# Altium schematic sheet Power_Supply.SchDoc
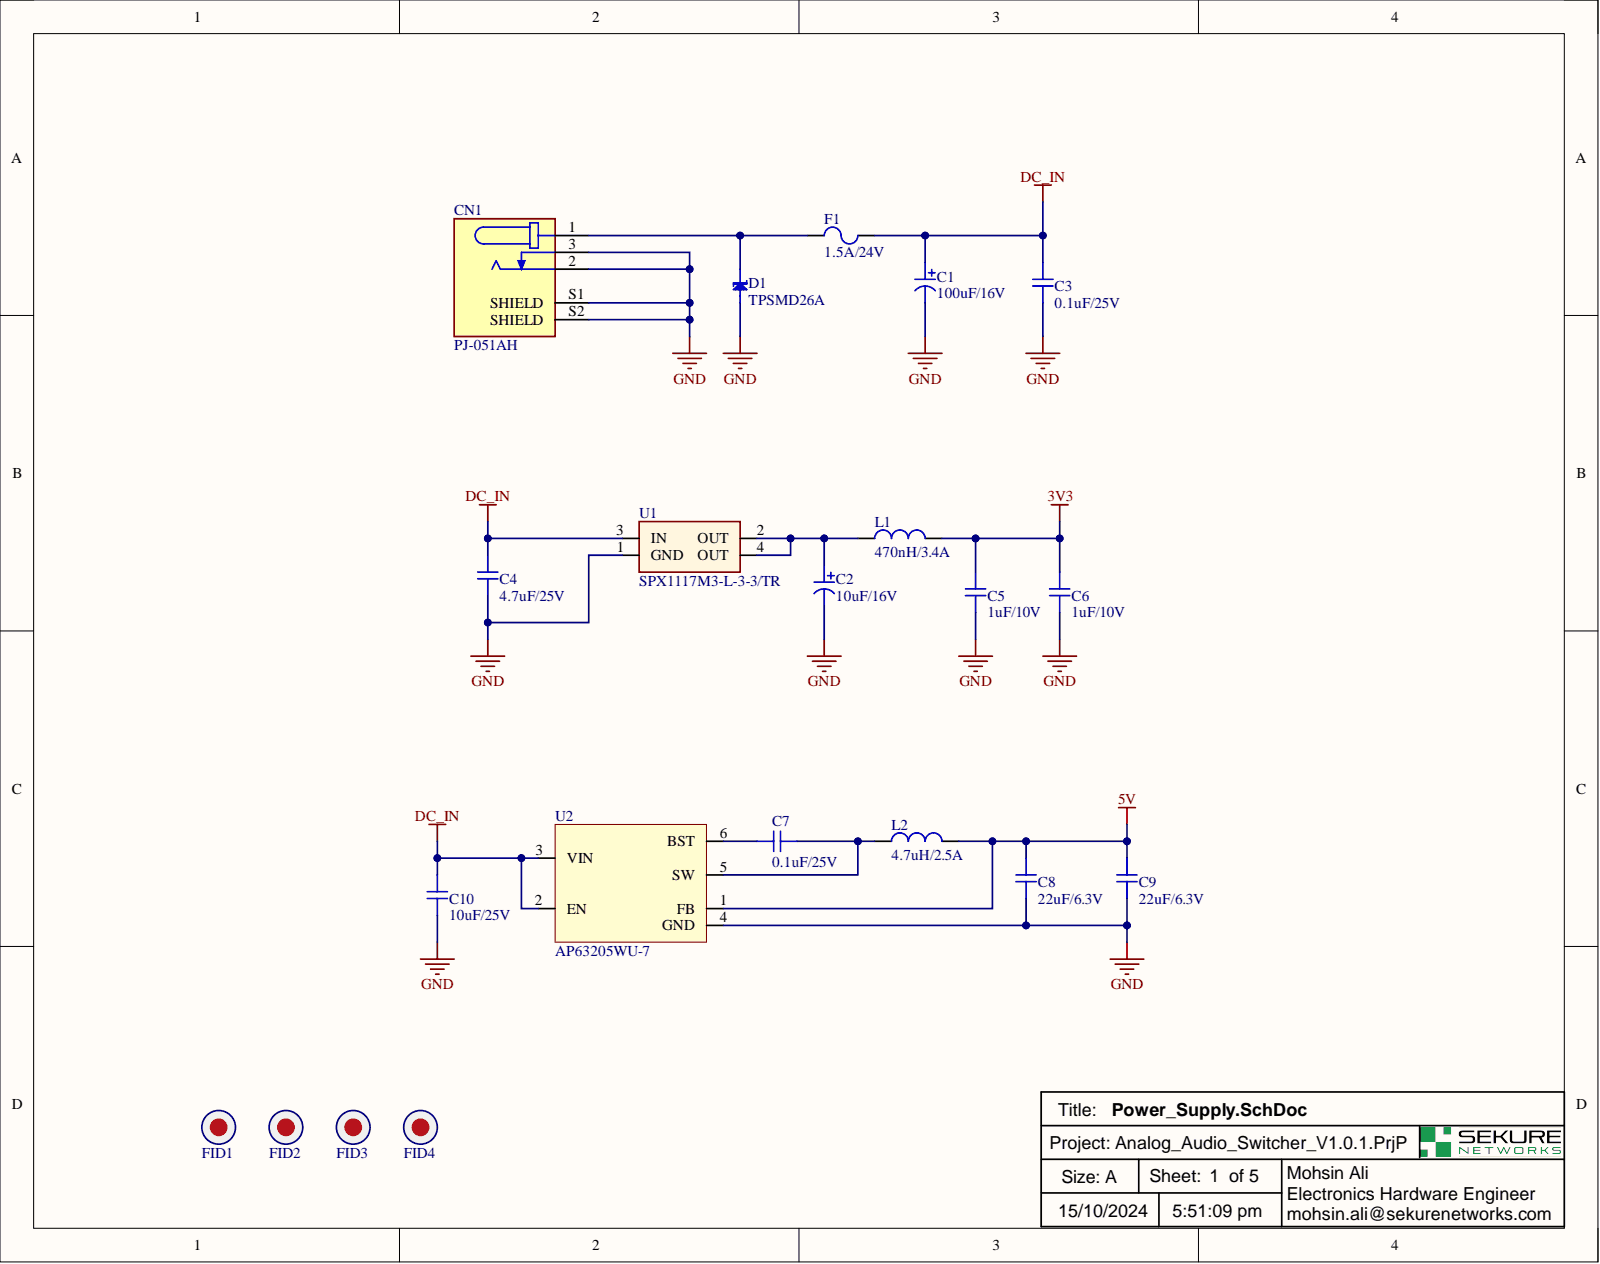
[diagram p0: Power_Supply.SchDoc]

## Parts
C1  value 100uF/16V  [CAP SMD 6.6 x 6.6]  (hidden comment: Capacitor)
C2  value 10uF/16V  [CAPAE4643X55M]  (hidden comment: Capacitor)
C3  value 0.1uF/25V  [CAP 0603]  (hidden comment: Ceramic Capacitor)
C4  value 4.7uF/25V  [CAP 0603]  (hidden comment: Ceramic Capacitor)
C5  value 1uF/10V  [CAP 0603]  (hidden comment: Ceramic Capacitor)
C6  value 1uF/10V  [CAP 0603]  (hidden comment: Ceramic Capacitor)
C7  value 0.1uF/25V  [CAP 0603]  (hidden comment: Ceramic Capacitor)
C8  value 22uF/6.3V  [CAP 0603]  (hidden comment: Ceramic Capacitor)
C9  value 22uF/6.3V  [CAP 0603]  (hidden comment: Ceramic Capacitor)
C10  value 10uF/25V  [CAP 0603]  (hidden comment: Capacitor)
CN1  value PJ-051AH  [PJ-051AH]  (hidden comment: Connector)
D1  value TPSMD26A  [DO-214AB(SMC)]  (hidden comment: TVS Diode)
F1  value 1.5A/24V  [0ZCG0150BF2C]  (hidden comment: Fuse)
FID1  Fiducal marks  [Fiducial mark]  (value not drawn)  (no BOM)
FID2  Fiducal marks  [Fiducial mark]  (value not drawn)  (no BOM)
FID3  Fiducal marks  [Fiducial mark]  (value not drawn)  (no BOM)
FID4  Fiducal marks  [Fiducial mark]  (value not drawn)  (no BOM)
L1  value 470nH/3.4A  [IND 0806]  (hidden comment: Inductor)
L2  value 4.7uH/2.5A  [B82472G6472M000]  (hidden comment: Inductor)
U1  value SPX1117M3-L-3-3/TR  [SOT-223]  (hidden comment: Regulator)
U2  value AP63205WU-7  [SOT23-6]  (hidden comment: Regulator)

## Nets
3V3: C5.2 C6.2 L1.2
5V: C8.1 C9.1 L2.2 U2.1(FB)
DC_IN: C1.1 C3.1 C4.1 C10.2 F1.2 U1.3(IN) U2.2(EN) U2.3(VIN)
GND: C1.2 C2.2 C3.2 C4.2 C5.1 C6.1 C8.2 C9.2 C10.1 CN1.2 CN1.3 CN1.S1(SHIELD) CN1.S2(SHIELD) D1.2 U1.1(GND) U2.4(GND)
NetC2_1: C2.1 L1.1 U1.2(OUT) U1.4(OUT)
NetC7_1: C7.1 U2.6(BST)
NetC7_2: C7.2 L2.1 U2.5(SW)
NetCN1_1: CN1.1 D1.1 F1.1

Not connected: FID1 0; FID2 0; FID3 0; FID4 0

## Library data of the parts
C1 = A765EB107M1CLAE025: Aluminum Electrolytic Capacitor, Polarized, Aluminum (solid Polymer), 16V, 20% +Tol, 20% -Tol, 100uF, Surface Mount, 2626; Manufacturer: KEMET; Capacitor Type: Aluminium; Category: Polymer Capacitors; Component Kind: Standard; ComponentLink1Description: https://datasheet.ciiva.com:443/pdfs/VipMasterIC/IC/KEME/KEME-S-A0009156844/KEME-S-A0009156844-1.pdf?src-supplier=IHS+Markit; ComponentLink1URL: https://datasheet.ciiva.com:443/pdfs/VipMasterIC/IC/KEME/KEME-S-A0009156844/KEME-S-A0009156844-1.pdf?src-supplier=IHS+Markit; ComponentLink2Description: https://datasheet.octopart.com/A765EB107M1CLAE025-KEMET-datasheet-140121990.pdf?src-supplier=Farnell; ComponentLink2URL: https://datasheet.octopart.com/A765EB107M1CLAE025-KEMET-datasheet-140121990.pdf?src-supplier=Farnell; ComponentLink3Description: http://www.farnell.com/datasheets/2095663.pdf?src-supplier=Element14; ComponentLink3URL: http://www.farnell.com/datasheets/2095663.pdf?src-supplier=Element14; ComponentLink4Description: https://api.kemet.com/component-edge/download/datasheet/A765EB107M1CLAE025.pdf?src-supplier=Digikey; ComponentLink4URL: https://api.kemet.com/component-edge/download/datasheet/A765EB107M1CLAE025.pdf?src-supplier=Digikey; ComponentLink5Description: http://www.kemet.com/docfinder?Partnumber=A765EB107M1CLAE025&src-supplier=Digikey; ComponentLink5URL: http://www.kemet.com/docfinder?Partnumber=A765EB107M1CLAE025&src-supplier=Digikey; ComponentLink6Description: https://datasheet.octopart.com/A765EB107M1CLAE025-KEMET-datasheet-74830868.pdf?src-supplier=Newark; ComponentLink6URL: https://datasheet.octopart.com/A765EB107M1CLAE025-KEMET-datasheet-74830868.pdf?src-supplier=Newark; ComponentLink7Description: https://datasheet.octopart.com/A765EB107M1CLAE025-KEMET-datasheet-165572898.pdf?src-supplier=TME; ComponentLink7URL: https://datasheet.octopart.com/A765EB107M1CLAE025-KEMET-datasheet-165572898.pdf?src-supplier=TME; ComponentLink8Description: https://datasheet.octopart.com/A765EB107M1CLAE025-KEMET-datasheet-138896607.pdf?src-supplier=Electro+Sonic; ComponentLink8URL: https://datasheet.octopart.com/A765EB107M1CLAE025-KEMET-datasheet-138896607.pdf?src-supplier=Electro+Sonic; Dielectric Material: Aluminium; DigiKey: 399-13672-1-ND; Library Name: Radial Capacitors.IntLib; P/N: A765EB107M1CLAE025; Pins: 2; Pricing: 1=0.69, 10=0.482, 50=0.4062, 100=0.3307, 500=0.27556 (USD); Reach SVHC Compliant: Yes; RoHS: Yes; RoHS Compliant: Yes; Stock: 4291; Supplier: Digikey
C2 = 865080340001: Cap, 10ΜF, 16V, SMD; Manufacturer: Wurth Electronics; Capacitance: 10uF; Impedance: 2.2R; Life (Hours): 2000Hour; Polarity: Polar; Ripple Current: 80mA; Tolerance: 20%; Case/Package: Radial; Category: Aluminum Electrolytic Capacitors; Component Kind: Standard; ComponentLink1Description: http://www.farnell.com/datasheets/1880118.pdf?src-supplier=Farnell; ComponentLink1URL: http://www.farnell.com/datasheets/1880118.pdf?src-supplier=Farnell; ComponentLink2Description: http://www.farnell.com/datasheets/1880118.pdf?src-supplier=Element14; ComponentLink2URL: http://www.farnell.com/datasheets/1880118.pdf?src-supplier=Element14; ComponentLink3Description: http://www.farnell.com/datasheets/1880118.pdf?src-supplier=Newark; ComponentLink3URL: http://www.farnell.com/datasheets/1880118.pdf?src-supplier=Newark; ComponentLink4Description: https://katalog.we-online.de/pbs/datasheet/865080340001.pdf?src-supplier=Digi-Key; ComponentLink4URL: https://katalog.we-online.de/pbs/datasheet/865080340001.pdf?src-supplier=Digi-Key; Diameter: 4mm; DigiKey: 732-8411-1-ND; Height: 5.5mm; Height - Seated (Max): 0.217inch; Lead Free: Lead Free; Library Name: Radial Capacitors.IntLib; Max Operating Temperature: 105°C; Min Operating Temperature: -55°C; Mount: Surface Mount; P/N: 865080340001; Package Quantity: 2000; Packaging: Cut Tape; Pricing 1: 1=0.18000, 50=0.15100, 100=0.13100, 250=0.11700, 500=0.10200, 1000=0.09700 (USD); REACH SVHC: Unknown; Ripple Current (AC): 80mA; RoHS: Yes; RoHS Compliant: Yes; Stock 1: 11596; Supplier 1: Digi-Key; Voltage Rating: 16V; Voltage Rating (DC): 16V
C3 C7 = CL10B104KA8NNNC: Multilayer Ceramic Capacitor, 0.1 uF, 25 V, ± 10%, X7R, 0603 [1608 Metric]; Manufacturer: Samsung; Material: 4241ConductorMaterial; Voltage: 25V; Case Code (Imperial): 0603; Case Code (Metric): 1608; Case/Package: 0603; Category: Ceramic Capacitors; Component Kind: Standard; ComponentLink1Description: https://datasheet.ciiva.com/25805/cl10b104ka8nnnc-25805120.pdf?src-supplier=Digikey; ComponentLink1URL: https://datasheet.ciiva.com/25805/cl10b104ka8nnnc-25805120.pdf?src-supplier=Digikey; ComponentLink2Description: https://datasheet.ciiva.com/26838/getdatasheetpartid-354050-26838409.pdf?src-supplier=Verical; ComponentLink2URL: https://datasheet.ciiva.com/26838/getdatasheetpartid-354050-26838409.pdf?src-supplier=Verical; Depth: 0.8mm; Dielectric: X7R; DigiKey: 1276-1006-1-ND; Height: 0.8mm; Lead Free: Lead Free; Length: 1.6mm; Library Name: Ceramic Capacitors.IntLib; Max Operating Temperature: 125°C; Min Operating Temperature: -55°C; Mounting Technology: Surface Mount; P/N: CL10B104KA8NNNC; Package Quantity: 1; Packaging: Tape and Reel; Pricing: 1=0.1, 10=0.024, 50=0.0136, 100=0.0105, 500=0.00792, 1000=0.00622 (USD); Radiation Hardening: No; RoHS: Yes; RoHS Compliant: Yes; Series: CL; Stock: 708971; Supplier: Digikey; Thickness: 0.035inch; Tolerance: 10%; Voltage Rating: 25V; Voltage Rating (DC): 25V; Width: 0.031inch
C4 = CL10A475MA8NQNC: Ceramic Capacitor, Multilayer, Ceramic, 25V, 20% +Tol, 20% -Tol, X5R, 15% TC, 4.7uF, Surface Mount, 0603; Manufacturer: Samsung; Multilayer: Yes; Capacitor Type: Ceramic; Case/Package: 0603; Category: Ceramic Capacitors; Component Kind: Standard; ComponentLink1Description: https://datasheet.ciiva.com:443/pdfs/VipMasterIC/IC/SEMS/SEMS-S-A0008384515/SEMS-S-A0008873310-1.pdf?src-supplier=IHS+Markit; ComponentLink1URL: https://datasheet.ciiva.com:443/pdfs/VipMasterIC/IC/SEMS/SEMS-S-A0008384515/SEMS-S-A0008873310-1.pdf?src-supplier=IHS+Markit; ComponentLink2Description: https://datasheet.ciiva.com/25787/cl10a475ma8nqnc-25787184.pdf?src-supplier=Digi-Key; ComponentLink2URL: https://datasheet.ciiva.com/25787/cl10a475ma8nqnc-25787184.pdf?src-supplier=Digi-Key; ComponentLink3Description: https://datasheet.ciiva.com/16437/cl10a475ma8nqnc-16437322.pdf?src-supplier=Future+Electronics; ComponentLink3URL: https://datasheet.ciiva.com/16437/cl10a475ma8nqnc-16437322.pdf?src-supplier=Future+Electronics; Dielectric Material: Ceramic; DigiKey: 1276-1903-1-ND; JESD-609 Code: e3; Library Name: Ceramic Capacitors.IntLib; Max Operating Temperature: 85°C; Min Operating Temperature: -55°C; Mounting Technology: Surface Mount; P/N: CL10A475MA8NQNC; Package Shape: Rectangular; Packaging: Tape and Reel; Pins: 2; Pricing: 1=0.27, 10=0.187, 50=0.1244, 100=0.1058, 500=0.07466, 1000=0.06533 (USD); Rated DC Voltage (URdc): 25V; Reach SVHC Compliant: Yes; RoHS: Yes; RoHS Compliant: Yes; Stock: 1889613; Supplier: Digi-Key; Temperature Characteristics Code: X5R; Terminal Finish: Nickel; Termination: Wraparound; Tolerance  (fill in as +-): 20%; Tolerance (fill in as +-): 20%
C5 C6 = CL10B105KP8NNNC: Multilayer Ceramic Capacitor, 1 uF, 10 V, ± 10%, X7R, 0603 [1608 Metric]; Manufacturer: Samsung; Material: 4241ConductorMaterial; Voltage Rating: 10V; Case Code (Imperial): 0603; Case Code (Metric): 1608; Case/Package: 0603; Category: Ceramic Capacitors; Component Kind: Standard; Construction: Flat; Depth: 0.8mm; Dielectric: X7R; DigiKey: 1276-1946-1-ND; Height: 0.8mm; Lead Free: Lead Free; Length: 1.6mm; Library Name: Ceramic Capacitors.IntLib; Max Operating Temperature: 125°C; Min Operating Temperature: -55°C; Mounting Technology: Surface Mount; P/N: CL10B105KP8NNNC; Package Quantity: 1; Packaging: Tape and Reel; Pricing: 1=0.1, 10=0.026, 50=0.0146, 100=0.0114, 500=0.00858, 1000=0.00674 (USD); Radiation Hardening: No; RoHS: Yes; RoHS Compliant: Yes; Series: CL; Stock: 7012743; Supplier: Digikey; Thickness: 0.035inch; Tolerance: 10%; Voltage Rating (DC): 10V; Width: 0.031inch
C8 C9 = C1608X5R0J226M080AC: Cap Cer 22uF 6.3V X5R 20% SMD 0603 85C Punched Paper T/R; Manufacturer: TDK; Capacitance: 22uF; Case Code (Imperial): 0603; Case Code (Metric): 1608; Case/Package: 0603; Component Kind: Standard; ComponentLink1Description: https://datasheet.ciiva.com/24454/c1608x5r0j226m080ac-24454269.pdf?src-supplier=Onlinecomponents.com; ComponentLink1URL: https://datasheet.ciiva.com/24454/c1608x5r0j226m080ac-24454269.pdf?src-supplier=Onlinecomponents.com; ComponentLink2Description: https://datasheet.ciiva.com/23802/1796654-23802759.pdf?src-supplier=Newark; ComponentLink2URL: https://datasheet.ciiva.com/23802/1796654-23802759.pdf?src-supplier=Newark; ComponentLink3Description: https://datasheet.ciiva.com/6288/tdkmlcccaprange-6288921.pdf?src-supplier=Digi-Key; ComponentLink3URL: https://datasheet.ciiva.com/6288/tdkmlcccaprange-6288921.pdf?src-supplier=Digi-Key; ComponentLink4Description: https://datasheet.ciiva.com/24216/mlcc-commercial-general-en-24216585.pdf?src-supplier=Mouser; ComponentLink4URL: https://datasheet.ciiva.com/24216/mlcc-commercial-general-en-24216585.pdf?src-supplier=Mouser; ComponentLink5Description: https://datasheet.ciiva.com/6288/tdkmlcccaprange-6288925.pdf?src-supplier=Digi-Key; ComponentLink5URL: https://datasheet.ciiva.com/6288/tdkmlcccaprange-6288925.pdf?src-supplier=Digi-Key; Depth: 0.8mm; Dielectric: X5R; Dielectric Material: Ceramic; DigiKey: 445-8028-1-ND; Height: 0.8mm; Lead Free: Lead Free; Length: 1.6mm; Library Name: Ceramic Capacitors.IntLib; Max Operating Temperature: 85°C; Min Operating Temperature: -55°C; Mount: Surface Mount; P/N: C1608X5R0J226M080AC; Package Quantity: 1; Packaging: Tape and Reel; Pricing 1: 1=0.35000, 10=0.24400, 50=0.17060, 100=0.14630, 500=0.10972, 1000=0.09264 (USD); REACH SVHC: No SVHC; Resistor Type: Ceramic; RoHS: Yes; RoHS Compliant: Yes; Series: C; Stock 1: 48288; Supplier 1: Digi-Key; Termination: SMD/SMT; Thickness: 0.039inch; Tolerance: 20%; Voltage: 6.3V; Voltage Rating: 6.3V; Voltage Rating (DC): 6.3V; Weight: 7.1E-05oz; Width: 0.031inch
C10 = GRM188R61E106MA73D: CAP CER 10UF 25V X5R 0603; Manufacturer: Murata; Tolerance: 20%; Capacitance: 10uF; Case Code (Imperial): 0603; Case Code (Metric): 1608; Case/Package: 0603; Component Kind: Standard; ComponentLink1Description: https://datasheet.ciiva.com/22619/c02e-22619674.pdf?src-supplier=Element14; ComponentLink1URL: https://datasheet.ciiva.com/22619/c02e-22619674.pdf?src-supplier=Element14; ComponentLink2Description: https://datasheet.ciiva.com/22776/608985-22776426.pdf?src-supplier=Farnell; ComponentLink2URL: https://datasheet.ciiva.com/22776/608985-22776426.pdf?src-supplier=Farnell; ComponentLink3Description: https://datasheet.ciiva.com/22776/c02e-22776427.pdf?src-supplier=Farnell; ComponentLink3URL: https://datasheet.ciiva.com/22776/c02e-22776427.pdf?src-supplier=Farnell; ComponentLink4Description: https://datasheet.ciiva.com/14906/partnumbering-e-01-14906429.pdf?src-supplier=Digi-Key; ComponentLink4URL: https://datasheet.ciiva.com/14906/partnumbering-e-01-14906429.pdf?src-supplier=Digi-Key; ComponentLink5Description: https://datasheet.ciiva.com/14906/partnumbering-e-01-14906431.pdf?src-supplier=Digi-Key; ComponentLink5URL: https://datasheet.ciiva.com/14906/partnumbering-e-01-14906431.pdf?src-supplier=Digi-Key; Composition: Ceramic; Depth: 0.8mm; Dielectric: X5R; DigiKey: 490-7202-1-ND; Height: 0.8mm; Lead Free: Lead Free; Length: 1.6mm; Library Name: Ceramic Capacitors.IntLib; Max Operating Temperature: 85°C; Min Operating Temperature: -55°C; Mount: Surface Mount; Number of Pins: 2; P/N: GRM188R61E106MA73D; Package Quantity: 1; Packaging: Tape and Reel; Pricing 1: 1=0.46000, 10=0.32200, 100=0.19320, 500=0.14488, 1000=0.12235 (USD); REACH SVHC: No SVHC; RoHS: Yes; Stock 1: 465147; Supplier 1: Digi-Key; Termination: SMD/SMT; Thickness: 0.031inch; Voltage: 25V; Voltage Rating: 25V; Voltage Rating (DC): 25V; Weight: 0.000222oz; Width: 0.031inch
CN1 = PJ-051AH: 2.0 x 6.0 mm, 5.0 A, Horizontal, Through Hole, Shielded, Dc Power Jack Connector; Manufacturer: CUI Devices; Category: Power Connectors; Component Kind: Standard; ComponentLink1Description: https://www.cuidevices.com/product/resource/digikeypdf/pj-051ah.pdf?src-supplier=Digikey; ComponentLink1URL: https://www.cuidevices.com/product/resource/digikeypdf/pj-051ah.pdf?src-supplier=Digikey; ComponentLink2Description: https://datasheet.octopart.com/PJ-051AH-CUI-Devices-datasheet-137760691.pdf?src-supplier=Future+Electronics; ComponentLink2URL: https://datasheet.octopart.com/PJ-051AH-CUI-Devices-datasheet-137760691.pdf?src-supplier=Future+Electronics; ComponentLink3Description: https://datasheet.octopart.com/PJ-051AH-CUI-Devices-datasheet-141000361.pdf?src-supplier=Master+Electronics; ComponentLink3URL: https://datasheet.octopart.com/PJ-051AH-CUI-Devices-datasheet-141000361.pdf?src-supplier=Master+Electronics; DigiKey: CP-051AH-ND; Library Name: Connectors.SchLib; P/N: PJ-051AH; Pricing: 1=0.63, 10=0.532, 25=0.5108, 50=0.4998, 100=0.4781, 250=0.43464, 500=0.39118, 1000=0.38261 (USD); RoHS: Yes; Stock: 71631; Supplier: Digikey
D1 = TPSMD26A: Trans Voltage Suppressor Diode, 3000W, 26V V(RWM), Unidirectional, 1 Element, Silicon, DO-214AB; Manufacturer: Littelfuse; Max Breakdown Voltage: 31.9V; Max Power Dissipation: 6.5W; Min Breakdown Voltage: 28.9V; Non-rep Peak Rev Power Dis-Max: 3kW; Number of Elements: 1; Package Body Material: Plastic; Reference Standard: AEC-Q101; Category: TVS Diodes; Component Kind: Standard; ComponentLink1Description: https://datasheet.ciiva.com:443/pdfs/VipMasterIC/IC/LFSI/LFSI-S-A0006157759/LFSI-S-A0006157759-1.pdf?src-supplier=IHS+Markit; ComponentLink1URL: https://datasheet.ciiva.com:443/pdfs/VipMasterIC/IC/LFSI/LFSI-S-A0006157759/LFSI-S-A0006157759-1.pdf?src-supplier=IHS+Markit; ComponentLink2Description: http://www.mouser.com/ds/2/240/Littelfuse_TVS_Diode_TPSMD_Datasheet.pdf-357416.pdf?src-supplier=Mouser; ComponentLink2URL: http://www.mouser.com/ds/2/240/Littelfuse_TVS_Diode_TPSMD_Datasheet.pdf-357416.pdf?src-supplier=Mouser; ComponentLink3Description: http://www.littelfuse.com/~/media/electronics/datasheets/tvs_diodes/littelfuse_tvs_diode_tpsmd_datasheet.pdf.pdf?src-supplier=Digikey; ComponentLink3URL: http://www.littelfuse.com/~/media/electronics/datasheets/tvs_diodes/littelfuse_tvs_diode_tpsmd_datasheet.pdf.pdf?src-supplier=Digikey; DigiKey: F6569CT-ND; Diode Element Material: SILICON; Diode Type: TRANS VOLTAGE SUPPRESSOR; JEDEC-95 Code: DO-214AB; JESD-30 Code: R-PDSO-J2; Library Name: Diode.IntLib; Max Operating Temperature: 150°C; Min Operating Temperature: -65°C; P/N: TPSMD26A; Package Shape: Rectangular; Package Style: SMALL OUTLINEMeter; Pins: 2; Polarity: Unidirectional; Pricing: 1=0.99, 10=0.81, 100=0.6297, 500=0.53378, 1000=0.43483 (USD); Rep Pk Reverse Voltage-Max: 26V; RoHS: Yes; RoHS Compliant: Yes; Stock: 492; Supplier: Digikey; Surface Mount: Yes; Technology: AVALANCHE; Terminal Form: J BEND; Terminal Position: DUAL
F1 = 0ZCG0150BF2C: PTC RESTTBLE 1.50A 24V CHIP 1812; Manufacturer: Bel; Category: PTC Resettable Fuses; Component Kind: Standard; ComponentLink1Description: http://www.farnell.com/datasheets/2571640.pdf?src-supplier=Farnell; ComponentLink1URL: http://www.farnell.com/datasheets/2571640.pdf?src-supplier=Farnell; ComponentLink2Description: https://www.belfuse.com/resources/CircuitProtection/datasheets/0ZCG%20Nov2016.pdf?src-supplier=Digi-Key; ComponentLink2URL: https://www.belfuse.com/resources/CircuitProtection/datasheets/0ZCG%20Nov2016.pdf?src-supplier=Digi-Key; ComponentLink3Description: http://www.belfuse.com/pdfs/0ZCG.pdf?src-supplier=Avnet; ComponentLink3URL: http://www.belfuse.com/pdfs/0ZCG.pdf?src-supplier=Avnet; ComponentLink4Description: http://www.farnell.com/datasheets/2571640.pdf?src-supplier=Newark; ComponentLink4URL: http://www.farnell.com/datasheets/2571640.pdf?src-supplier=Newark; ComponentLink5Description: http://www.farnell.com/datasheets/2571640.pdf?src-supplier=Element14; ComponentLink5URL: http://www.farnell.com/datasheets/2571640.pdf?src-supplier=Element14; DigiKey: 507-1773-1-ND; Library Name: Diode.IntLib; P/N: 0ZCG0150BF2C; Pricing 1: 1=0.23000, 5=0.22400, 10=0.21400, 50=0.19120, 100=0.17810, 250=0.15828, 500=0.13190, 1000=0.12531 (USD); RoHS: Yes; Stock 1: 290389; Supplier 1: Digi-Key
FID1-FID4 = Fiducial mark: Fiducial mark mini  in top placement.; Number: 1; Library Name: Connectors.IntLib
L1 = 1286AS-H-R47M=P2: Inductor Power Shielded Wirewound 0.47uH 20% 1MHz Metal 3.2A 0.052Ohm DCR 0806 T/R; Manufacturer: Murata; Core Material: Ferrite; Current Rating: 3.4A; DC Resistance (DCR): 52mR; Max DC Current: 3.8A; Case Code (Imperial): 0806; Case Code (Metric): 2016; Case/Package: 0806; Category: Fixed Inductors; Component Kind: Standard; ComponentLink1Description: http://www.farnell.com/datasheets/2021974.pdf?src-supplier=Farnell; ComponentLink1URL: http://www.farnell.com/datasheets/2021974.pdf?src-supplier=Farnell; ComponentLink2Description: http://www.toko.co.jp/products/pdf/inductors/dfe201612c.pdf?src-supplier=Avnet; ComponentLink2URL: http://www.toko.co.jp/products/pdf/inductors/dfe201612c.pdf?src-supplier=Avnet; ComponentLink3Description: http://search.murata.co.jp/Ceramy/image/img/P02/J(E)TE243A-0010_DFE201612C_reference.pdf?src-supplier=Digikey; ComponentLink3URL: http://search.murata.co.jp/Ceramy/image/img/P02/J(E)TE243A-0010_DFE201612C_reference.pdf?src-supplier=Digikey; ComponentLink4Description: http://www.toko.co.jp/products/pdf/inductors/dfe201612c.pdf?src-supplier=Digikey; ComponentLink4URL: http://www.toko.co.jp/products/pdf/inductors/dfe201612c.pdf?src-supplier=Digikey; Depth: 1.6mm; DigiKey: 490-10606-1-ND; Height - Seated (Max): 0.047inch; Length: 0.079inch; Library Name: Inductors_NEW.IntLib; Max Operating Temperature: 85°C; Min Operating Temperature: -40°C; Mounting Technology: Surface Mount; P/N: 1286AS-H-R47M=P2; Packaging: Cut Tape; Pricing: 1=0.34, 10=0.264, 25=0.2388, 50=0.2214, 100=0.2051, 250=0.18544, 500=0.17182, 1000=0.15914 (USD); RoHS: Yes; RoHS Compliant: Yes; Series: DFE201612C; Shielding: Shielded; Stock: 11624; Supplier: Digikey; Test Frequency: 1MHz; Tolerance: 20%; Width: 0.063inch
L2 = B82472G6472M000: Ind Power Shielded 4.7uH 20% 100KHz Ferrite 2.5A Blister T/R; Manufacturer: EPCOS - TDK Electronics; Component Kind: Standard; DigiKey: 495-77197-2-ND; Library Name: Inductors_NEW.IntLib; P/N: B82472G6472M000
U1 = SPX1117M3-L-3-3/TR: Linear Voltage Regulator IC Positive Fixed 1 Output 800mA SOT-223-3; Manufacturer: MaxLinear, Inc.; Accuracy: 1%; Dropout Voltage: 1.3V; Input Bias Current: 4mA; Max Input Voltage: 15V; Max Output Voltage: 3.3V; Min Input Voltage: 3.8V; Number of Outputs: 1; Case/Package: SOT-223; Category: Voltage Regulators - Linear; Component Kind: Standard; ComponentLink1Description: https://datasheet.ciiva.com/2064/reg1117-2064563.pdf?src-supplier=Digi-Key; ComponentLink1URL: https://datasheet.ciiva.com/2064/reg1117-2064563.pdf?src-supplier=Digi-Key; DigiKey: 1016-1848-2-ND; Lead Free: Lead Free; Library Name: Regulators.IntLib; Max Operating Temperature: 125°C; Max Output Current: 800mA; Min Current Limit: 800mA; Min Operating Temperature: 0°C; Min Output Voltage: 3.3V; Nominal Output Voltage: 3.3V; Number of Pins: 4; Number of Regulators: 1; Output Current: 800mA; Output Type: Fixed; Output Voltage: 3.3V; Output Voltage Accuracy: 1%; P/N: SPX1117M3-L-3-3/TR; Package Quantity: 80; Packaging: Rail/Tube; Polarity: Positive; Pricing 1: 1=3.08000, 10=2.76700, 25=2.61040, 100=2.22390, 250=2.08820, 500=1.82718, 1000=1.72375 (USD); Quiescent Current: 4mA; Radiation Hardening: No; REACH SVHC: No SVHC; RoHS: Yes; RoHS Compliant: Yes; Stock 1: 3710; Supplier 1: Digi-Key
U2 = AP63205WU-7: AP63205 Series 32 V 2 A 1.1 MHz Synchronous Buck Converter -TSOT-26; Manufacturer: Diodes; Max Duty Cycle: 100%; Max Supply Voltage: 32V; Min Supply Voltage: 3.8V; Nominal Supply Current: 22uA; Number of Outputs: 1; Output Current: 2A; Output Voltage: 5V; Switching Frequency: 1.1MHz; Category: Voltage Regulators - Switching; Component Kind: Standard; ComponentLink1Description: https://www.diodes.com/assets/Datasheets/AP63200-AP63201-AP63203-AP63205.pdf?src-supplier=Digikey; ComponentLink1URL: https://www.diodes.com/assets/Datasheets/AP63200-AP63201-AP63203-AP63205.pdf?src-supplier=Digikey; DigiKey: AP63205WU-7DICT-ND; Height: 1mm; Library Name: Regulators.IntLib; Max Operating Temperature: 85°C; Min Operating Temperature: -40°C; P/N: AP63205WU-7; Pricing: 1=0.87, 10=0.761, 25=0.7152, 100=0.5836, 250=0.54212, 500=0.46136, 1000=0.36909 (USD); RoHS: Yes; Stock: 22124; Supplier: Digikey
